# Altium schematic sheet Battery_Gate_Driver.SchDoc
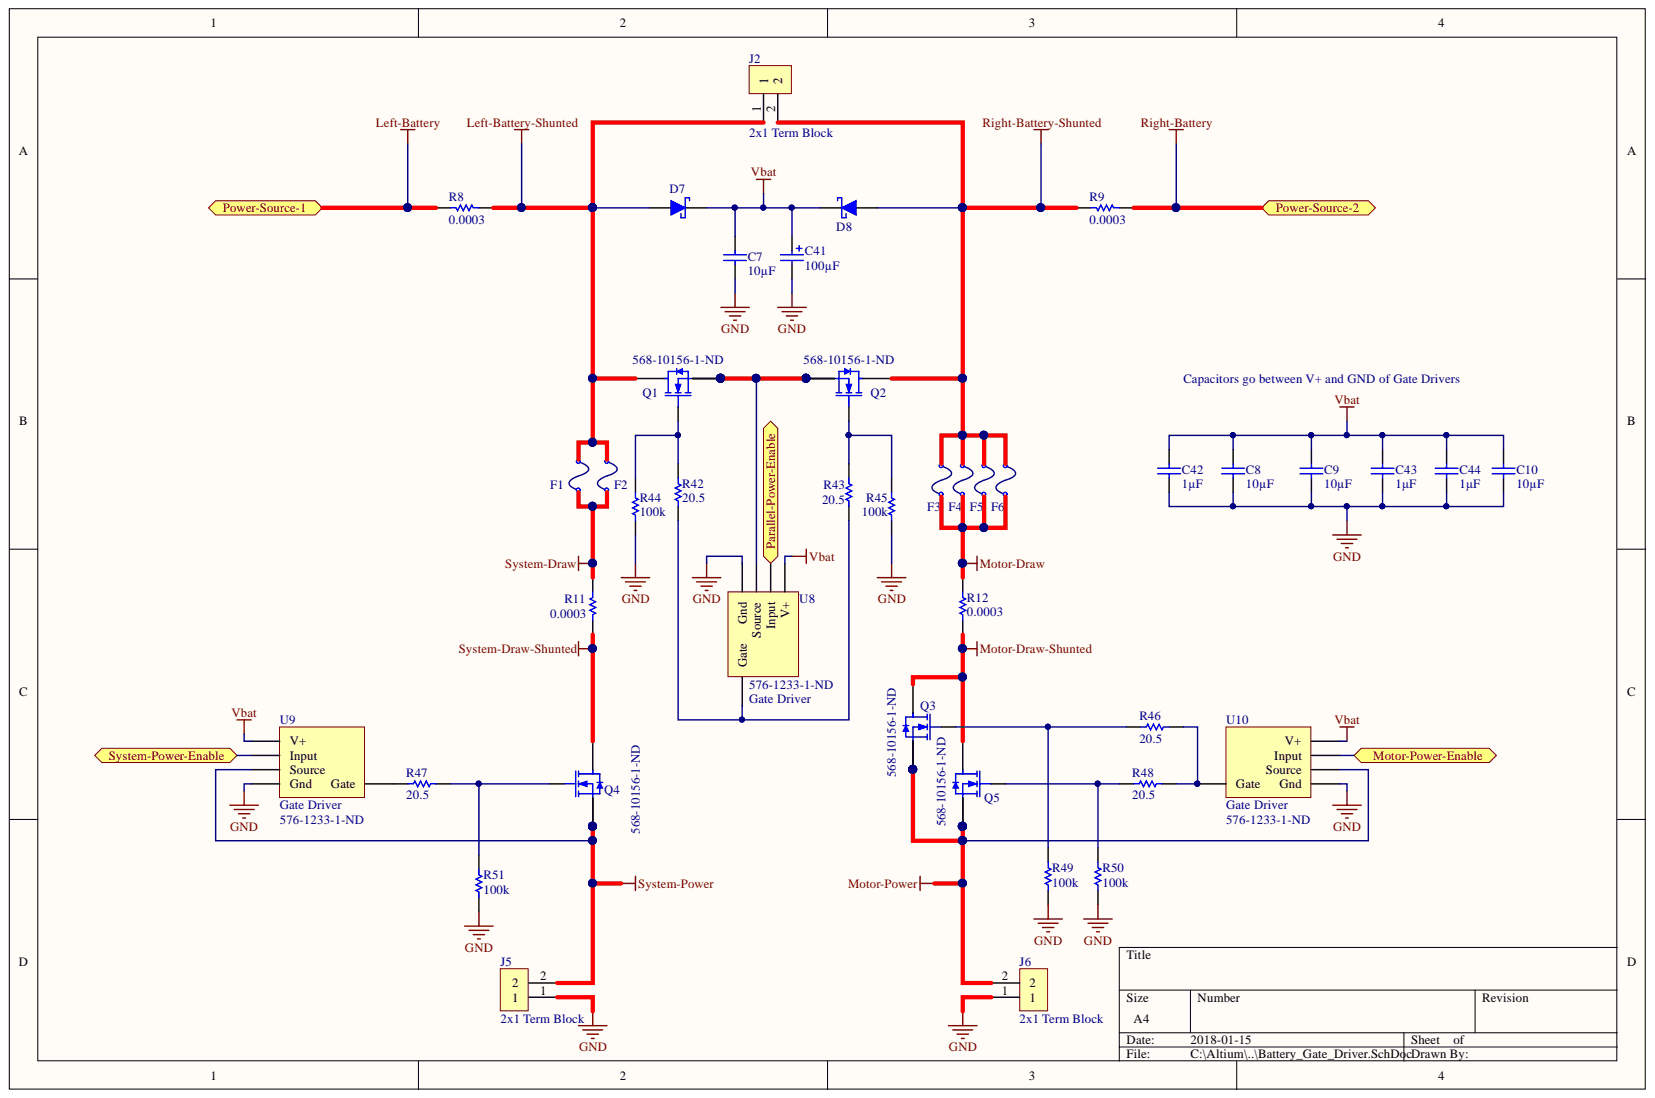
[diagram p0: Battery_Gate_Driver.SchDoc]

## Parts
C7  10µF  [CAPC3216N(1206")]
C8  10µF  [CAPC3216N(1206")]
C9  10µF  [CAPC3216N(1206")]
C10  10µF  [CAPC3216N(1206")]
C41  100µF  [Radial_CAN_8.3mm_10.5mm]
C42  1μF  [CAPC1608N(0603")]
C43  1μF  [CAPC1608N(0603")]
C44  1μF  [CAPC1608N(0603")]
D7  CUS08F30H3FCT-ND  [SC-76]  (value not drawn)
D8  CUS08F30H3FCT-ND  [SC-76]  (value not drawn)
F1  MINI  [MINI BLADE FUSEHOLDER]  (value not drawn)
F2  MINI  [MINI BLADE FUSEHOLDER]  (value not drawn)
F3  MINI  [MINI BLADE FUSEHOLDER]  (value not drawn)
F4  MINI  [MINI BLADE FUSEHOLDER]  (value not drawn)
F5  MINI  [MINI BLADE FUSEHOLDER]  (value not drawn)
F6  MINI  [MINI BLADE FUSEHOLDER]  (value not drawn)
J2  2x1 Term Block  [2x1 Terminal Blocks EM2929]
J5  2x1 Term Block  [2x1 Terminal Blocks EM2929]
J6  2x1 Term Block  [2x1 Terminal Blocks EM2929]
Q1  Supplier Part Number 1: 568-10156-1-ND  [LFPAK-PowerSO8]  (hidden comment: MOSFET N-Channel, 40V, 100A, 272W)
Q2  Supplier Part Number 1: 568-10156-1-ND  [LFPAK-PowerSO8]  (hidden comment: MOSFET N-Channel, 40V, 100A, 272W)
Q3  Supplier Part Number 1: 568-10156-1-ND  [LFPAK-PowerSO8]  (hidden comment: MOSFET N-Channel, 40V, 100A, 272W)
Q4  MOSFET N-Channel, 40V, 100A, 272W  [LFPAK-PowerSO8]  (value not drawn)
Q5  Supplier Part Number 1: 568-10156-1-ND  [LFPAK-PowerSO8]  (hidden comment: MOSFET N-Channel, 40V, 100A, 272W)
R8  0.0003  [RESC6332N(2512")]
R9  0.0003  [RESC6332N(2512")]
R11  0.0003  [RESC6332N(2512")]
R12  0.0003  [RESC6332N(2512")]
R42  20.5  [RESC1608N(0603")]
R43  20.5  [RESC1608N(0603")]
R44  100k  [RESC2012N(0805")]
R45  100k  [RESC2012N(0805")]
R46  20.5  [RESC1608N(0603")]
R47  20.5  [RESC1608N(0603")]
R48  20.5  [RESC1608N(0603")]
R49  100k  [RESC2012N(0805")]
R50  100k  [RESC2012N(0805")]
R51  100k  [RESC2012N(0805")]
U8  Gate Driver  Supplier Part Number 1: 576-1233-1-ND  [SOC 8]
U9  Gate Driver  Supplier Part Number 1: 576-1233-1-ND  [SOC 8]
U10  Gate Driver  Supplier Part Number 1: 576-1233-1-ND  [SOC 8]

## Ports
Motor-Power-Enable, Parallel-Power-Enable, Power-Source-1, Power-Source-2, System-Power-Enable

## Nets
GND: C7.1 C8.1 C9.1 C10.1 C41.2 C42.1 C43.1 C44.1 J5.1 J6.1 R44.1 R45.1 R49.1 R50.1 R51.1 U8.4(Gnd) U9.4(Gnd) U10.4(Gnd)
Left-Battery-Shunted: D7.A F1.2 F2.2 J2.1 Q1.5 R8.1
Motor-Draw: F3.1 F4.1 F5.1 F6.1 R12.1
Motor-Draw-Shunted: Q3.5 Q5.5 R12.2
Motor-Power: J6.2 Q3.1 Q3.2 Q3.3 Q5.1 Q5.2 Q5.3 U10.3(Source)
Right-Battery-Shunted: D8.A F3.2 F4.2 F5.2 F6.2 J2.2 Q2.5 R9.2
System-Draw: F1.1 F2.1 R11.2
System-Draw-Shunted: Q4.5 R11.1
System-Power: J5.2 Q4.1 Q4.2 Q4.3 U9.3(Source)
Vbat: C7.2 C8.2 C9.2 C10.2 C41.1 C42.2 C43.2 C44.2 D7.K D8.K U8.1(V+) U9.1(V+) U10.1(V+)
Left-Battery: R8.2 ; port Power-Source-1
Motor-Power-Enable: U10.2(Input) ; port Motor-Power-Enable
Parallel-Power-Enable: U8.2(Input) ; port Parallel-Power-Enable
Right-Battery: R9.1 ; port Power-Source-2
System-Power-Enable: U9.2(Input) ; port System-Power-Enable
NetQ1_1: Q1.1 Q1.2 Q1.3 Q2.1 Q2.2 Q2.3 U8.3(Source)
NetQ1_4: Q1.4 R42.2 R44.2
NetQ2_4: Q2.4 R43.2 R45.2
NetQ3_4: Q3.4 R46.2 R49.2
NetQ4_4: Q4.4 R47.1 R51.2
NetQ5_4: Q5.4 R48.2 R50.2
NetR42_1: R42.1 R43.1 U8.5
NetR46_1: R46.1 R48.1 U10.5
NetR47_2: R47.2 U9.5

## Library data of the parts
C7-C10 = 10uF 50V 1206 SMD, Ceramic: Capacitor, Ceramic, 50V, +/- 10%, 1206 SMD, X5R; Component Kind: Standard; PackageDescription: Chip Capacitor; Published: 14-06-01; Supplier 1: Digi-Key; Supplier Part Number 1: 1276-2876-1-ND; Value: 10µF; Library Name: AUVic_Integrated_Library_Capacitors.IntLib; Publisher: AUVic
C41 = 100uF, 50V, 20%, Alum, SMD: Capacitor, Polarized, Aluminum, 50V; Component Kind: Standard; Date Created: 2016-06-25; PackageDescription: Can; Supplier 1: Digi-Key; Supplier Part Number 1: 732-8461-1-ND; Value: 100µF; Created By: Ashwin Sira; Library Name: AUVic_Integrated_Library_Capacitors.IntLib; Publisher: AUVic
C42-C44 = 1uF 50V 0603 SMD, Ceramic: Capacitor, Ceramic, 50V, +/- 10%, 0603 SMD, X5R; Component Kind: Standard; Date Created: 2017-10-26; PackageDescription: Chip Capacitor; Supplier 1: Digi-Key; Supplier Part Number 1: 1276-1860-6-ND; Value: 1μF; Created By: Lyden Smith; Library Name: AUVic_Integrated_Library_Capacitors.IntLib
D7 D8 = CUS08F30H3FCT-ND: Diode, Schottky, 30V, 800mA; Component Kind: Standard; Date Created: 2016-09-09; Supplier 1: Digi-Key; Supplier Part Number 1: CUS08F30H3FCT-ND; Created By: Andy Bates; Library Name: AUVic_Integrated_Library_Diodes.IntLib; Publisher: AUVic
F1-F6 = MINI, ATC, ATO, etc. Blade Fuse: Blade Fuse Holder, 30A; Component Kind: Standard; Date Created: 2018-01-11; Supplier 1: Digi-Key; Supplier Part Number 1: 36-3557-2-ND; Type: MINI; Created By: Lyden Smith; Library Name: AUVic_Integrated_Library_Fuses.IntLib
J2 J5 J6 = 2x1 Terminal Block, 65A, 6-20AWG: Made by: Lyden Smith; Component Kind: Standard; Date Made: 2017-11-13; Supplier 1: Digi-Key; Supplier Part Number 1: 283-4339-ND; Library Name: AUVic_Integrated_Library_Connectors.IntLib
Q1-Q5 = MOSFET N-Channel, 40V, 100A, 272W: MOSFET N-Channel, 40V, 100A, 272W, Enh-Mode; Latest_Revision_By: Ashwin Sira; Latest_Revision_Note: Attached footprint; MFG: NXP Semiconductors; MFG#: PSMN1R8-40YLC,115; Component Kind: Standard; Latest_Revision_Date: 2016_04_26; Reviewed_Date: 2016_04_26; Supplier 1: Digi-Key; Created By: Ashwin Sira; Library Name: AUVic_Integrated_Library_Transistors.IntLib; Publisher: AUVic; Reviewed_By: Ashwin Sira
  Q4: Supplier Part Number 1: 568-10156-1-ND
R8 R9 R11 R12 = 0.0003R, 1%, 3W, 2512 SMD: Made By: Ashwin Sira; Owned By: AUVic; Component Kind: Standard; Created On: 14-05-31; Description: 0.0003R, 1%, 3W, 2512 SMD; Last Edited: 14-05-31; Library Name: AUVic_Integrated_Library_Resistors.IntLib; Supplier 1: Digi-Key; Value: 0.0003
R42 R43 R46-R48 = 20.5 Ohm, 1%, 1/10W , 0603, SMD: Component Kind: Standard; Date Created: 2017-06-23; Description: 20.5 Ohm, 1%, 1/10W , 0603, SMD; Supplier 1: Digi-Key; Supplier Part Number 1: P20.5HCT-ND; Value: 20.5; Created By: Gabriel Green; Library Name: AUVic_Integrated_Library_Resistors.IntLib; Publisher: AUVic
R44 R45 R49-R51 = 100kR, 1%, 1/4W, 0805 SMD: Made By: Ashwin Sira; Owned By: AUVic; Component Kind: Standard; Created On: 14-05-31; Description: 100kR, 1%, 1/4W, 0805 SMD; Last Edited: 14-05-31; Library Name: AUVic_Integrated_Library_Resistors.IntLib; Supplier 1: Digi-Key; Supplier Part Number 1: 311-1.00MCRCT-ND; Value: 100k
U8-U10 = Gate Driver TTL Logic 3 - 30V: Component Kind: Standard; Supplier 1: Digi-Key; Library Name: AUVic_Integrated_Library_Gate_Drivers.IntLib
